# Altium schematic sheet MANEA_TCAD_PROIECT_Sheet2.SchDoc(MANEA_TCAD_PROIECT_Sheet2) (continued)
## Library data of the parts (continued)
R7 R8 R15 R16 = CR0402-J/-2R7GLF: CR0402 FIXED RESISTOR 0402 (1005Metric) Chip Resistor ±5% -250/ +500ppm/C 2.7Ohm 0.063W 1/16W; Manufacturer: Bourns; Max Power Dissipation: 63mW; Power Rating: 62.5mW; Temperature Coefficient: 500ppm/°C; Voltage Rating: 50V; Case Code (Imperial): 0402; Case Code (Metric): 1005; Case/Package: 0402; Category: Chip SMD Resistors; China RoHS: Non-Compliant; Component Kind: Standard; ComponentLink1Description: https://datasheet.octopart.com:443/CR0402-J--2R7GLF-Bourns-datasheet-159578630.pdf?src-supplier=IHS; ComponentLink1URL: https://datasheet.octopart.com:443/CR0402-J--2R7GLF-Bourns-datasheet-159578630.pdf?src-supplier=IHS; ComponentLink2Description: https://datasheet.octopart.com:443/CR0402-J--2R7GLF-Bourns-datasheet-181955239.pdf?src-supplier=IHS; ComponentLink2URL: https://datasheet.octopart.com:443/CR0402-J--2R7GLF-Bourns-datasheet-181955239.pdf?src-supplier=IHS; ComponentLink3Description: https://datasheet.octopart.com:443/CR0402-J--2R7GLF-Bourns-datasheet-68301632.pdf?src-supplier=Electro+Sonic; ComponentLink3URL: https://datasheet.octopart.com:443/CR0402-J--2R7GLF-Bourns-datasheet-68301632.pdf?src-supplier=Electro+Sonic; ComponentLink4Description: https://datasheet.octopart.com/CR0402-J/-2R7GLF-Bourns-datasheet-8723995.pdf; ComponentLink4URL: https://datasheet.octopart.com/CR0402-J/-2R7GLF-Bourns-datasheet-8723995.pdf; ComponentLink5Description: http://sigma.octopart.com:80/conflict_mineral_statement/Bourns-company-36.pdf?src-supplier=Compliance+Statements; ComponentLink5URL: http://sigma.octopart.com:80/conflict_mineral_statement/Bourns-company-36.pdf?src-supplier=Compliance+Statements; Composition: Thick Film; Contact Plating: Tin; Country of Origin: Taiwan; Depth: 500µm; Export Control Classification Number (ECCN) Code: EAR99; Harmonized Tariff Schedule (HTS) Code: 8533.21.00.30; Height: 320µm; Introduction Date: 2003-04-07; Length: 1mm; Lifecycle Status: Production; Manufacturer Part Number: CR0402-J/-2R7GLF; Max Operating Temperature: 155°C; Military Standard: Not; Min Operating Temperature: -55°C; Mounting Technology: Surface Mount; Number of Terminals: 2; Power Dissipation: 63mW; Pricing: 1=0.1, 10=0.02, 25=0.0144, 50=0.0114, 100=0.0091, 250=0.00696, 500=0.00574, 1000=0.0048, 5000=0.00333 (USD); Radiation Hardening: No; REACH SVHC: Yes; RoHS: Compliant; Supplier: DigiKey; Supplier Part Number: CR0402-J/-2R7GLFDKR-ND; Termination: SMD/SMT; Tolerance: 5%; Width: 500µm; Working Voltage: 50V; Library Name: MANEA_TCAD_PROIECT_Schlib.SchLib
SPK1-SPK4 = ASE02808MR-LW150-R: Speakers & Transducers 28mm SPEAKER w/ENCL 2 W 8 OHM 82 DB; Manufacturer: PUI Audio; Cable Length: 150mm; Max Power Dissipation: 3W; Power Rating: 2W; Self Resonant Frequency: 22Hz; Shape: Rectangular, Square; Category: Speakers; Component Kind: Standard; ComponentLink1Description: https://datasheet.octopart.com:443/ASE02808MR-LW150-R-PUI-Audio-datasheet-128089182.pdf?src-supplier=JRH+Electronics; ComponentLink1URL: https://datasheet.octopart.com:443/ASE02808MR-LW150-R-PUI-Audio-datasheet-128089182.pdf?src-supplier=JRH+Electronics; ComponentLink2Description: https://datasheet.octopart.com:443/ASE02808MR-LW150-R-PUI-Audio-datasheet-128089185.pdf?src-supplier=Sager+Electronics; ComponentLink2URL: https://datasheet.octopart.com:443/ASE02808MR-LW150-R-PUI-Audio-datasheet-128089185.pdf?src-supplier=Sager+Electronics; Height: 14.8mm; Length: 31mm; Manufacturer Part Number: ASE02808MR-LW150-R; Mounting Technology: Flanges; Pricing: 1=7.56, 10=5.802, 42=4.95976, 84=4.60714, 126=4.41524, 252=4.10972, 504=3.83006, 1008=3.65106 (USD); REACH SVHC: Yes; RoHS: Compliant; Stock: 2641; Supplier: DigiKey; Supplier Part Number: 668-1431-ND; Termination: Wire Leads; Width: 28mm; Library Name: MANEA_TCAD_PROIECT_Schlib.SchLib
T1 = PE-68386NL: Pulse Transformer 1: 1 1500VDC 0.6Ohm Prim. DCR 0.6Ohm Sec. DCR 6 Terminal SMD Automotive; Manufacturer: Yageo Group; DC Resistance (DCR): 600mΩ; Isolation Voltage: 1500Vrms; Number of Circuits: 1; Shielding: Unshielded; Turns Ratio: 1:1; Value: 785µH; Case/Package: SMD/SMT; Category: Transformers; Component Kind: Standard; ComponentLink1Description: https://datasheet.octopart.com:443/PE-68386NL-Pulse-datasheet-155502643.pdf?src-supplier=Upverter; ComponentLink1URL: https://datasheet.octopart.com:443/PE-68386NL-Pulse-datasheet-155502643.pdf?src-supplier=Upverter; ComponentLink2Description: https://datasheet.octopart.com:443/PE-68386NL-Pulse-datasheet-151940171.pdf?src-supplier=IHS; ComponentLink2URL: https://datasheet.octopart.com:443/PE-68386NL-Pulse-datasheet-151940171.pdf?src-supplier=IHS; ComponentLink3Description: https://datasheet.octopart.com:443/PE-68386NL-Pulse-datasheet-134530794.pdf?src-supplier=ODG+(Origin+Data+Global); ComponentLink3URL: https://datasheet.octopart.com:443/PE-68386NL-Pulse-datasheet-134530794.pdf?src-supplier=ODG+(Origin+Data+Global); ComponentLink4Description: https://datasheet.octopart.com:443/PE-68386NL-Pulse-datasheet-8399838.pdf?src-supplier=Factory+Futures; ComponentLink4URL: https://datasheet.octopart.com:443/PE-68386NL-Pulse-datasheet-8399838.pdf?src-supplier=Factory+Futures; ComponentLink5Description: https://datasheet.octopart.com:443/PE-68386NL-Pulse-datasheet-145346898.pdf?src-supplier=Future+Electronics; ComponentLink5URL: https://datasheet.octopart.com:443/PE-68386NL-Pulse-datasheet-145346898.pdf?src-supplier=Future+Electronics; ComponentLink6Description: http://sigma.octopart.com:80/conflict_mineral_statement/Yageo-company-3.pdf?src-supplier=Compliance+Statements; ComponentLink6URL: http://sigma.octopart.com:80/conflict_mineral_statement/Yageo-company-3.pdf?src-supplier=Compliance+Statements; ComponentLink7Description: http://sigma.octopart.com:80/rohs_statement/Yageo-company-2.pdf?src-supplier=Compliance+Statements; ComponentLink7URL: http://sigma.octopart.com:80/rohs_statement/Yageo-company-2.pdf?src-supplier=Compliance+Statements; Country of Origin: Mainland China; Depth: 8.64mm; Export Control Classification Number (ECCN) Code: EAR99; Height: 2.5mm; Introduction Date: 1998-06-30; Length: 8.6mm; Lifecycle Status: Production; Manufacturer Part Number: PE-68386NL; Max Operating Temperature: 130°C; Min Operating Temperature: -40°C; Mounting Technology: Surface Mount; Pins: 6; Pricing: 1=1, 10=8.84, 25=8.53, 50=7.92, 100=7.32, 500=7.16 (EUR); Radiation Hardening: No; REACH SVHC: Yes; RoHS: Compliant; Schedule B: 8504500000, 8504500000|8504500000, 8504500000|8504500000|8504500000, 8504500000|8504500000|8504500000|8504500000; Stock: 604; Supplier: Farnell; Supplier Part Number: PE-68386NL; Termination: SMD/SMT; Width: 6.7mm; Library Name: MANEA_TCAD_PROIECT_Schlib.SchLib
